# Altium schematic sheet FPGA-MGT.SchDoc(FPGA-MGT)
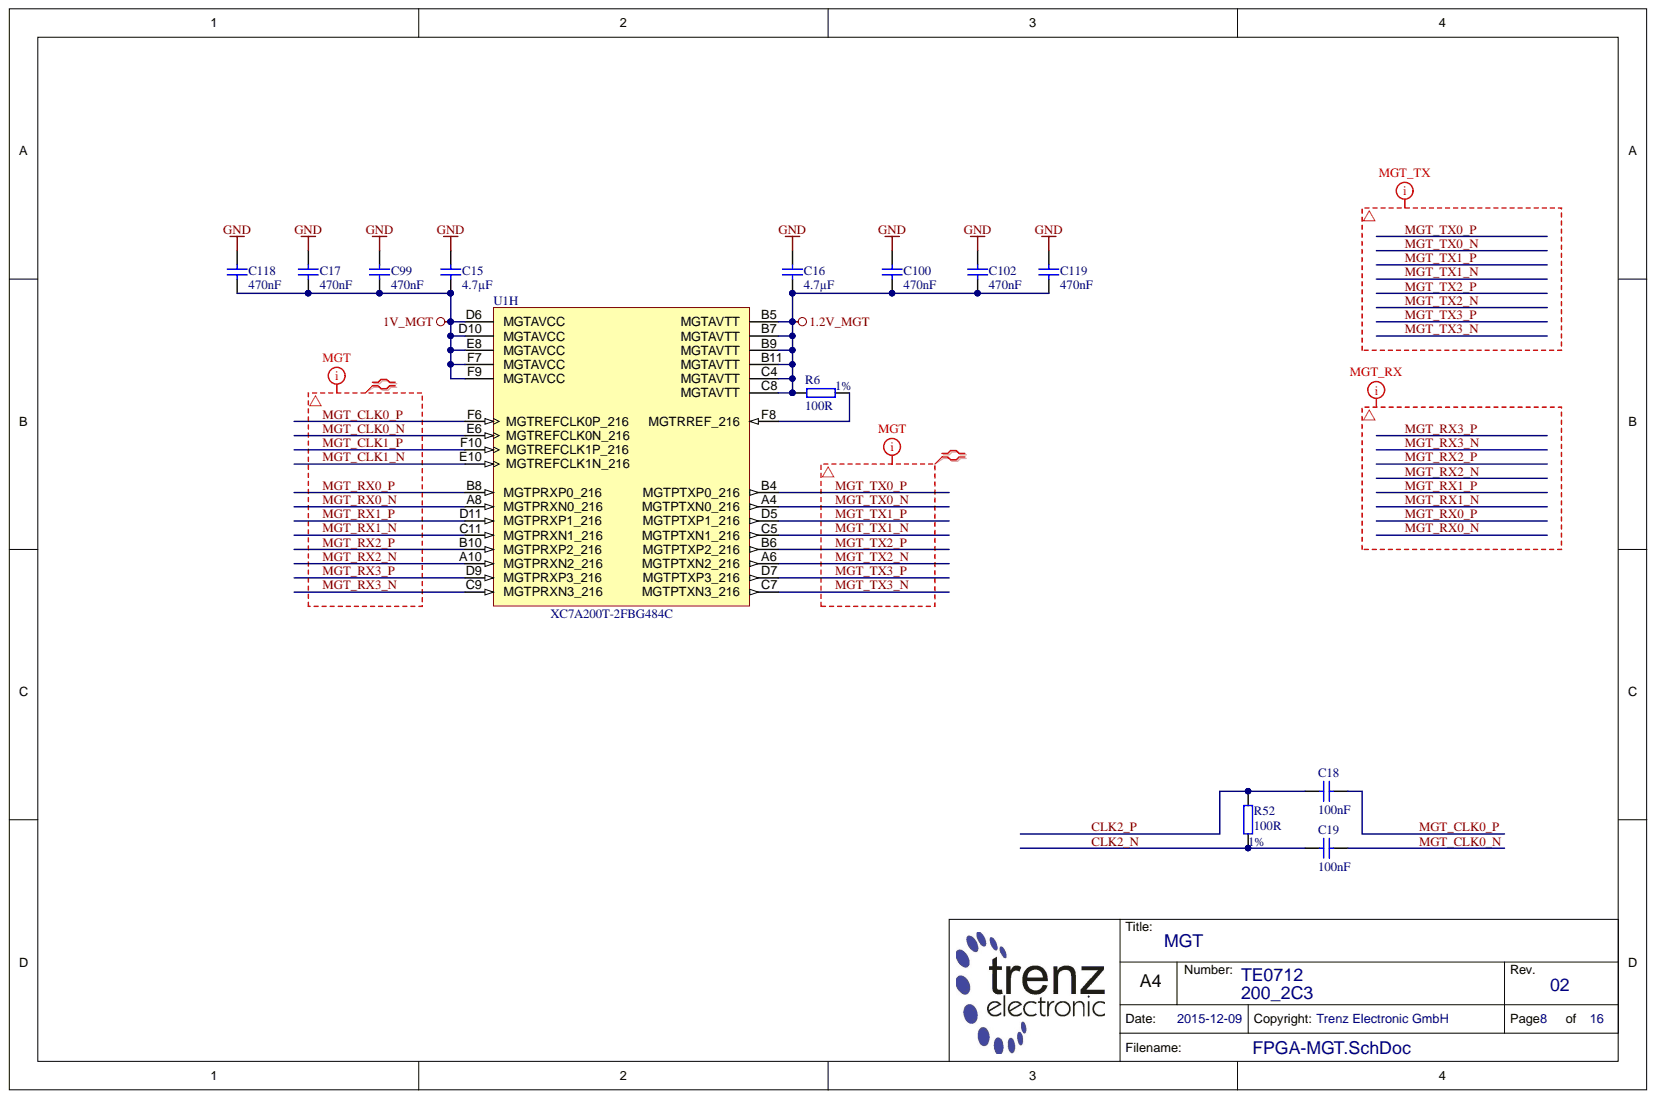
[diagram p0: FPGA-MGT.SchDoc]

## Parts
C15  4.7µF  [CAPC1005X60N]
C16  4.7µF  [CAPC1005X60N]
C17  470nF  [CAPC0603X33N]
C18  100nF  [CAPC0603X33N]
C19  100nF  [CAPC0603X33N]
C99  470nF  [CAPC0603X33N]
C100  470nF  [CAPC0603X33N]
C102  470nF  [CAPC0603X33N]
C118  470nF  [CAPC0603X33N]
C119  470nF  [CAPC0603X33N]
R6  100R  Tolerance: 1%  [RESC1005X40N]
R52  100R  Tolerance: 1%  [RESC1005X40N]
U1  XC7A200T-2FBG484C  [BGA484C100P22X22_2300X2300X254N]  (part H)

## Nets
1.2V_MGT: C16.2 C100.2 C102.2 C119.2 R6.1 U1.B5(MGTAVTT) U1.B7(MGTAVTT) U1.B9(MGTAVTT) U1.B11(MGTAVTT) U1.C4(MGTAVTT) U1.C8(MGTAVTT)
1V_MGT: C15.2 C17.2 C99.2 C118.2 U1.D6(MGTAVCC) U1.D10(MGTAVCC) U1.E8(MGTAVCC) U1.F7(MGTAVCC) U1.F9(MGTAVCC)
GND: C15.1 C16.1 C17.1 C99.1 C100.1 C102.1 C118.1 C119.1
CLK2_N: C19.1 R52.1
CLK2_P: C18.1 R52.2
MGT_CLK0_N: C19.2 U1.E6(MGTREFCLK0N_216)
MGT_CLK0_P: C18.2 U1.F6(MGTREFCLK0P_216)
MGT_CLK1_N: U1.E10(MGTREFCLK1N_216)
MGT_CLK1_P: U1.F10(MGTREFCLK1P_216)
MGT_RX0_N: U1.A8(MGTPRXN0_216)
MGT_RX0_P: U1.B8(MGTPRXP0_216)
MGT_RX1_N: U1.C11(MGTPRXN1_216)
MGT_RX1_P: U1.D11(MGTPRXP1_216)
MGT_RX2_N: U1.A10(MGTPRXN2_216)
MGT_RX2_P: U1.B10(MGTPRXP2_216)
MGT_RX3_N: U1.C9(MGTPRXN3_216)
MGT_RX3_P: U1.D9(MGTPRXP3_216)
MGT_TX0_N: U1.A4(MGTPTXN0_216)
MGT_TX0_P: U1.B4(MGTPTXP0_216)
MGT_TX1_N: U1.C5(MGTPTXN1_216)
MGT_TX1_P: U1.D5(MGTPTXP1_216)
MGT_TX2_N: U1.A6(MGTPTXN2_216)
MGT_TX2_P: U1.B6(MGTPTXP2_216)
MGT_TX3_N: U1.C7(MGTPTXN3_216)
MGT_TX3_P: U1.D7(MGTPTXP3_216)
NetR6_2: R6.2 U1.F8(MGTRREF_216)
N00776:
N00777:
N00778:
N00779:
N00780:
N00781:

## Library data of the parts
C15 C16 = 24719: KON 4.7µF 6.3V X5R SMD 20%; Database Table Name: C_1005_0402; Mixed: SMT; Preferred: Yes; Value: 4.7u; Voltage: 6.3; AN: 24719; Case: 1005 (0402); Component Kind: Standard; Library Name: TE.DbLib; Technology: X5R; Tolerance: 20%
C17 C99 C100 C102 C118 C119 = 24921: KON 470nF X5R 6.3V SMD 20%; Database Table Name: C_0603_0201; Mixed: SMT; Preferred: Yes; Value: 470n; AN: 24921; Case: 0603 (0201); Component Kind: Standard; Library Name: TE.DbLib; Technology: X5R; Tolerance: 20%; Voltage: 6.3V
C18 C19 = 24915: KON 100nF 6.3V X5R SMD 10%; Database Table Name: C_0603_0201; Mixed: SMT; Preferred: Yes; Value: 100n; AN: 24915; Case: 0603 (0201); Component Kind: Standard; Library Name: TE.DbLib; Technology: X5R; Tolerance: 10%; Voltage: 6.3V
R6 R52 = 22507: WID SMD 100R 1% 0,063W; Database Table Name: R_1005_0402; H-ART: RC1005F101CS; Mixed: SMT; Preferred: Yes; Value: 100; AN: 22507; Case: 1005 (0402); Component Kind: Standard; Library Name: TE.DbLib
U1 = 25321: Database Table Name: PROG_LOGIC; Mixed: SMT; Trenz-Stock: Yes; AN: 25321; Case: BGA484; Component Kind: Standard; Description: XC7A200T-2FBG484C; H-ART: XC7A200T-2FBG484C; Library Name: TE.DbLib; HelpURL: B:\AD\adlib\datasheet\XC7
